# Altium schematic sheet USB.SchDoc(USB)
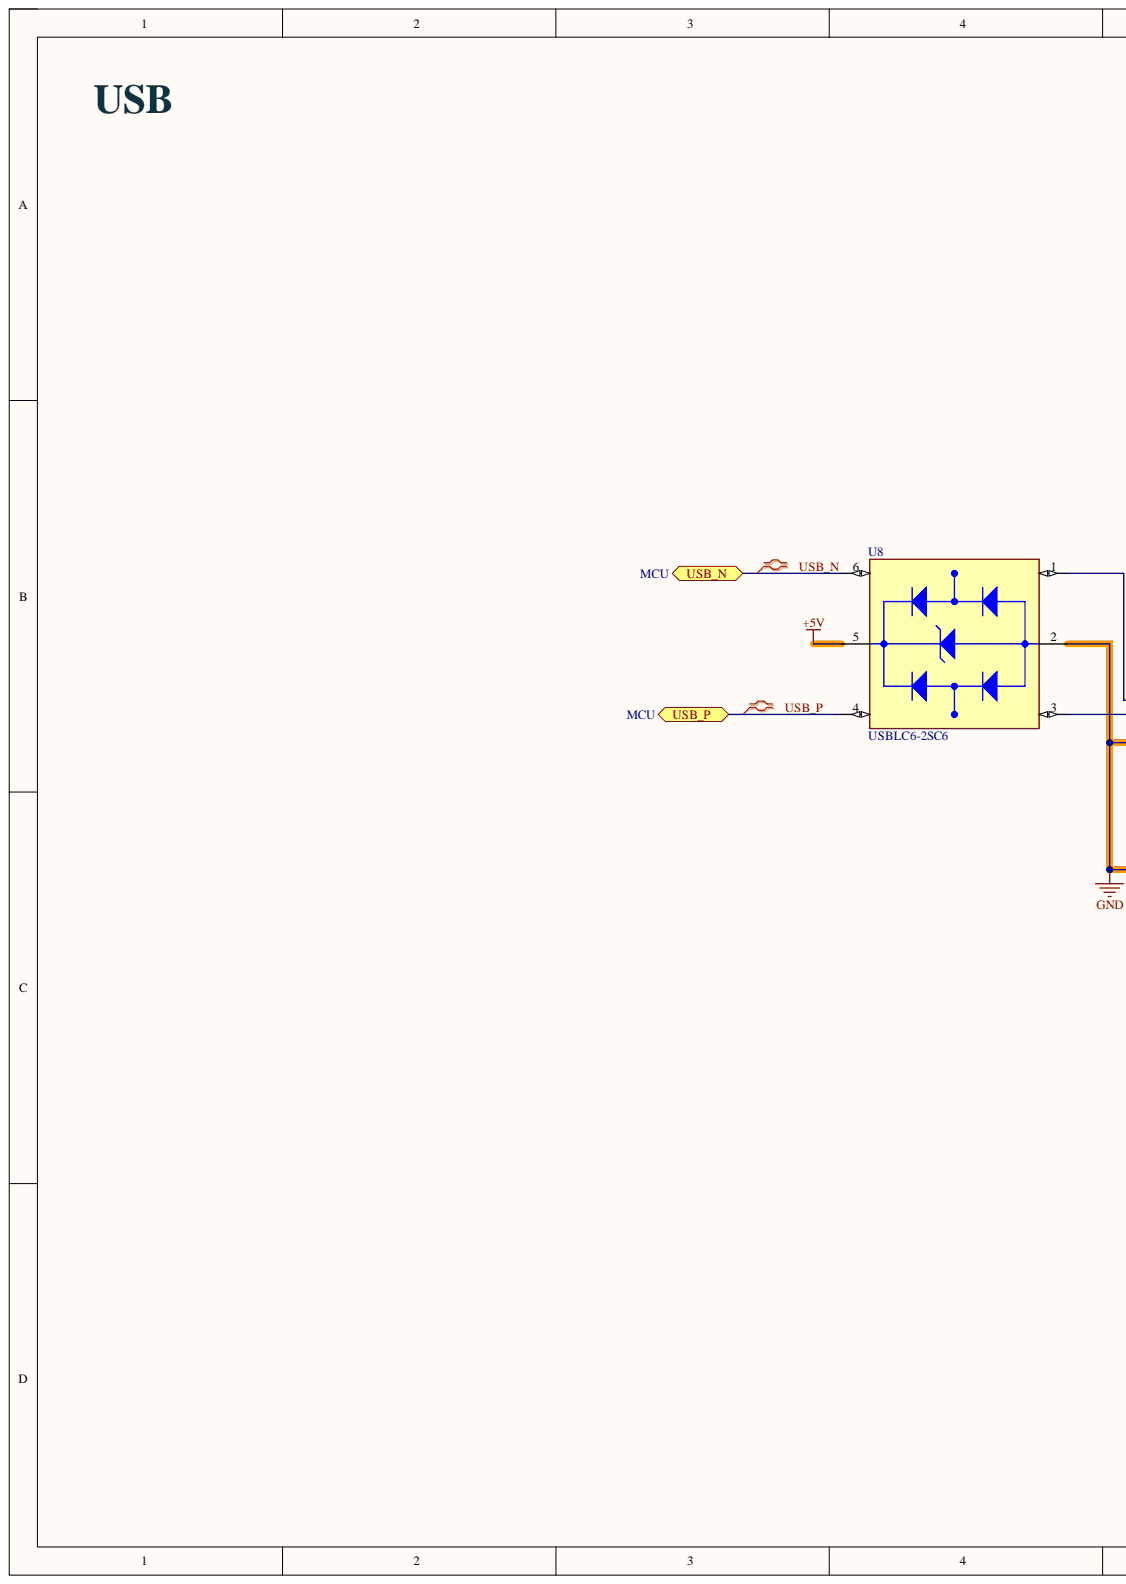
[diagram p0: USB.SchDoc, left]
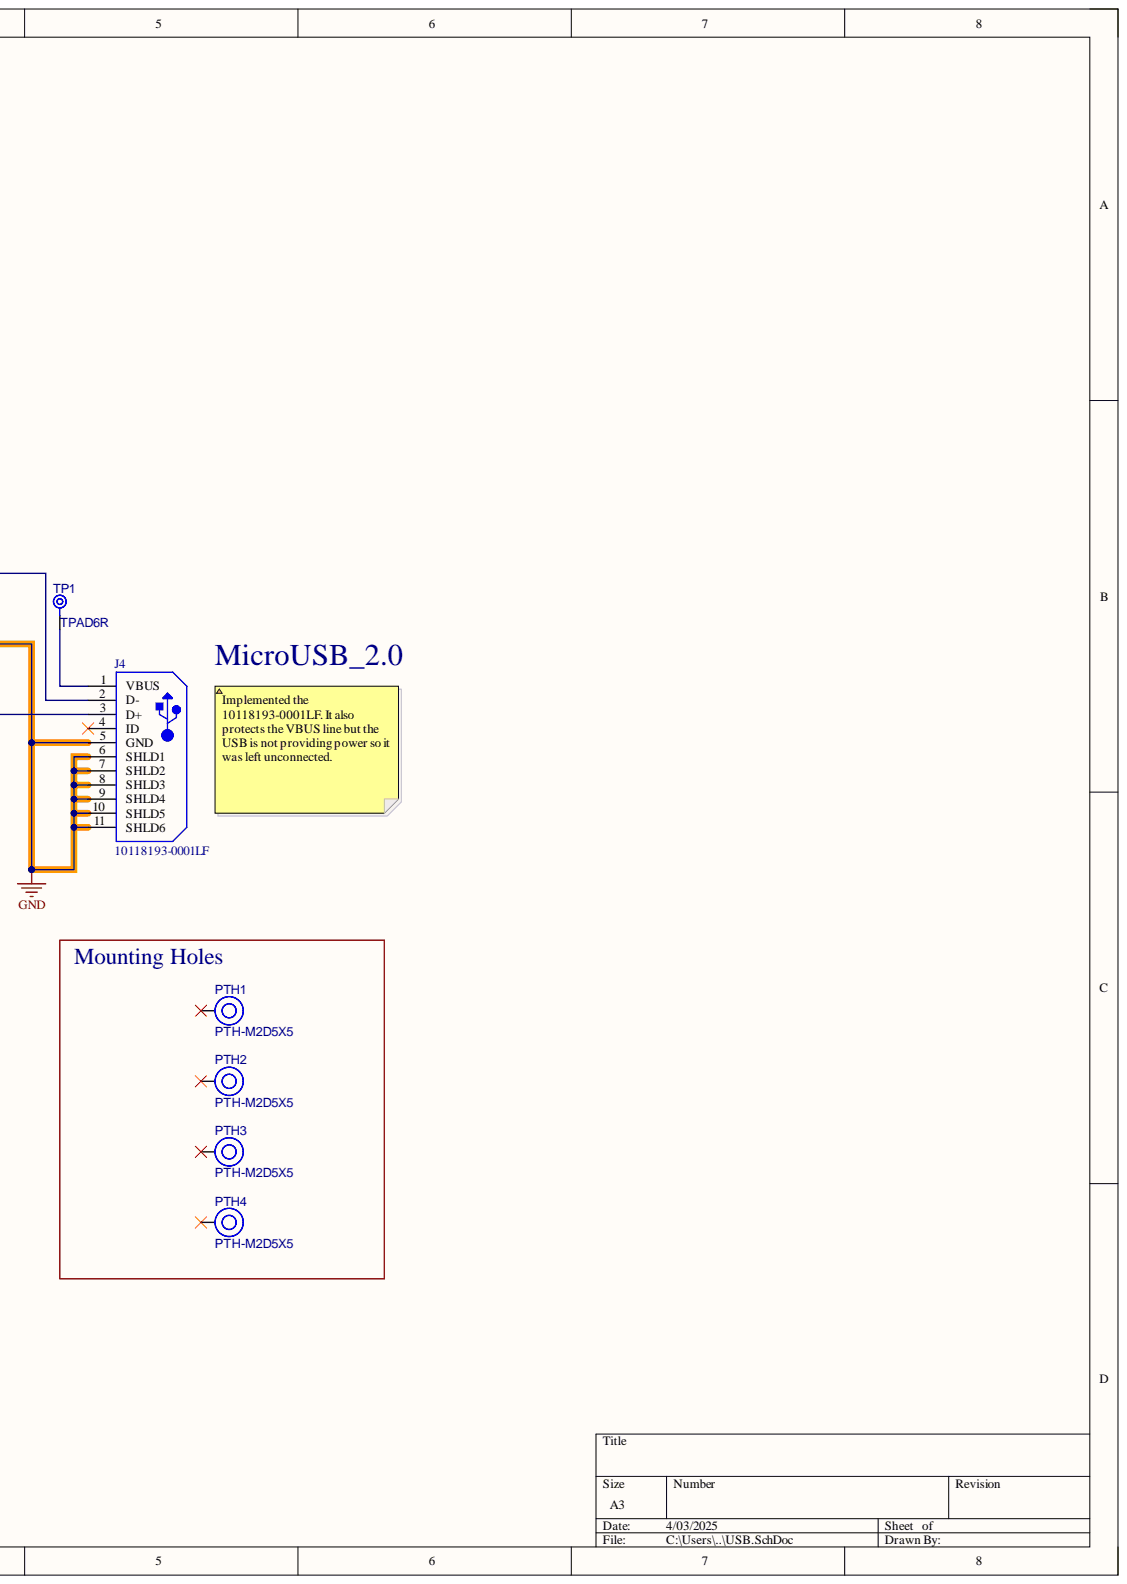
[diagram p1: USB.SchDoc, right]

## Parts
J4  10118193-0001LF  [FCI-10118193-0001LF-5_V]
PTH1  PTH-M2D5X5  [PTH-M2D5X5]
PTH2  PTH-M2D5X5  [PTH-M2D5X5]
PTH3  PTH-M2D5X5  [PTH-M2D5X5]
PTH4  PTH-M2D5X5  [PTH-M2D5X5]
TP1  TPAD6R  [TP06R]  (no BOM)
U8  USBLC6-2SC6  [SOT95P280X145-6N]

## Ports
USB_N, USB_P

## Nets
+5V: U8.5
GND: J4.5(GND) J4.6(SHLD1) J4.7(SHLD2) J4.8(SHLD3) J4.9(SHLD4) J4.10(SHLD5) J4.11(SHLD6) U8.2
USB_N: U8.6 ; port USB_N
USB_P: U8.4 ; port USB_P
NetJ4_1: J4.1(VBUS) TP1.1
NetJ4_2: J4.2(D-) U8.1
NetJ4_3: J4.3(D+) U8.3

Not connected: J4 4(ID); PTH1 1; PTH2 1; PTH3 1; PTH4 1

## Library data of the parts
J4 = CMP-2000-05119-1: Micro USB B Type Receptacle, -55 to 85 degC, 5-Pin SMD, RoHS, Tape and Reel; Brand: FCI; Connector Type: MicroUSBTypeBreceptacle; Current Rating: 1.8A; Gender: Female; Insulation Resistance: 1000MOhms; Mounting Angle: Right; Number of Contacts: 5Contact; Color: Black; Component Kind: Standard; Contact Material: CopperAlloy; Contact Plating: Gold; Datasheet URL: http://datasheet.octopart.com/10118193-0001LF-FCI-datasheet-55953155.pdf; Datasheet Version: Rev. C, 05/2015; Factory Pack Quantity: 1200; Flammability Rating: UL94V-0; Library Name: Altium Content Vault; Manufacturer: FCI; Manufacturer URL: http://www.fci.com/; Operating Temperature Range: -55Cto+85C; Packaging: Reel; Product: MicroUSBTypeBConnectors; Product Category: USBConnectors; RoHS: Details; Standard: USB2.0; Termination Style: SMD/SMT
PTH1-PTH4 = CMP-00028-00004-2: M2 PTH (2.5mm), 5mm pad; Component Kind: Standard; Pins: 1; Library Name: Personal Repository
TP1 = CMP-00028-00002-2: 0.6mm round; Pins: 1; Library Name: Personal Repository
U8 = CMP-004-00031-1: 17V Clamp 5A (8/20Âµs) Ipp Tvs Diode Surface Mount SOT-23-6; MF: STMicroelectronics; Availability: In Stock; Case/Package: SOT-23-6 STMicroelectronics; Check_prices: https://www.snapeda.com/parts/USBLC6-2SC6/STMicroelectronics/view-part/?ref=eda; Component Kind: Standard; Component Type: Standard; Design Item ID: USBLC6-2SC6; MP: USBLC6-2SC6; Pins: 6; Price: None; Purchase-URL: https://pricing.snapeda.com/search/part/USBLC6-2SC6/?ref=eda; SnapEDA_Link: https://www.snapeda.com/parts/USBLC6-2SC6/STMicroelectronics/view-part/?ref=snap; Source: USBLC6-2SC6.SchLib; Library Name: Personal Repository
